# Altium schematic sheet Schematic.SchDoc
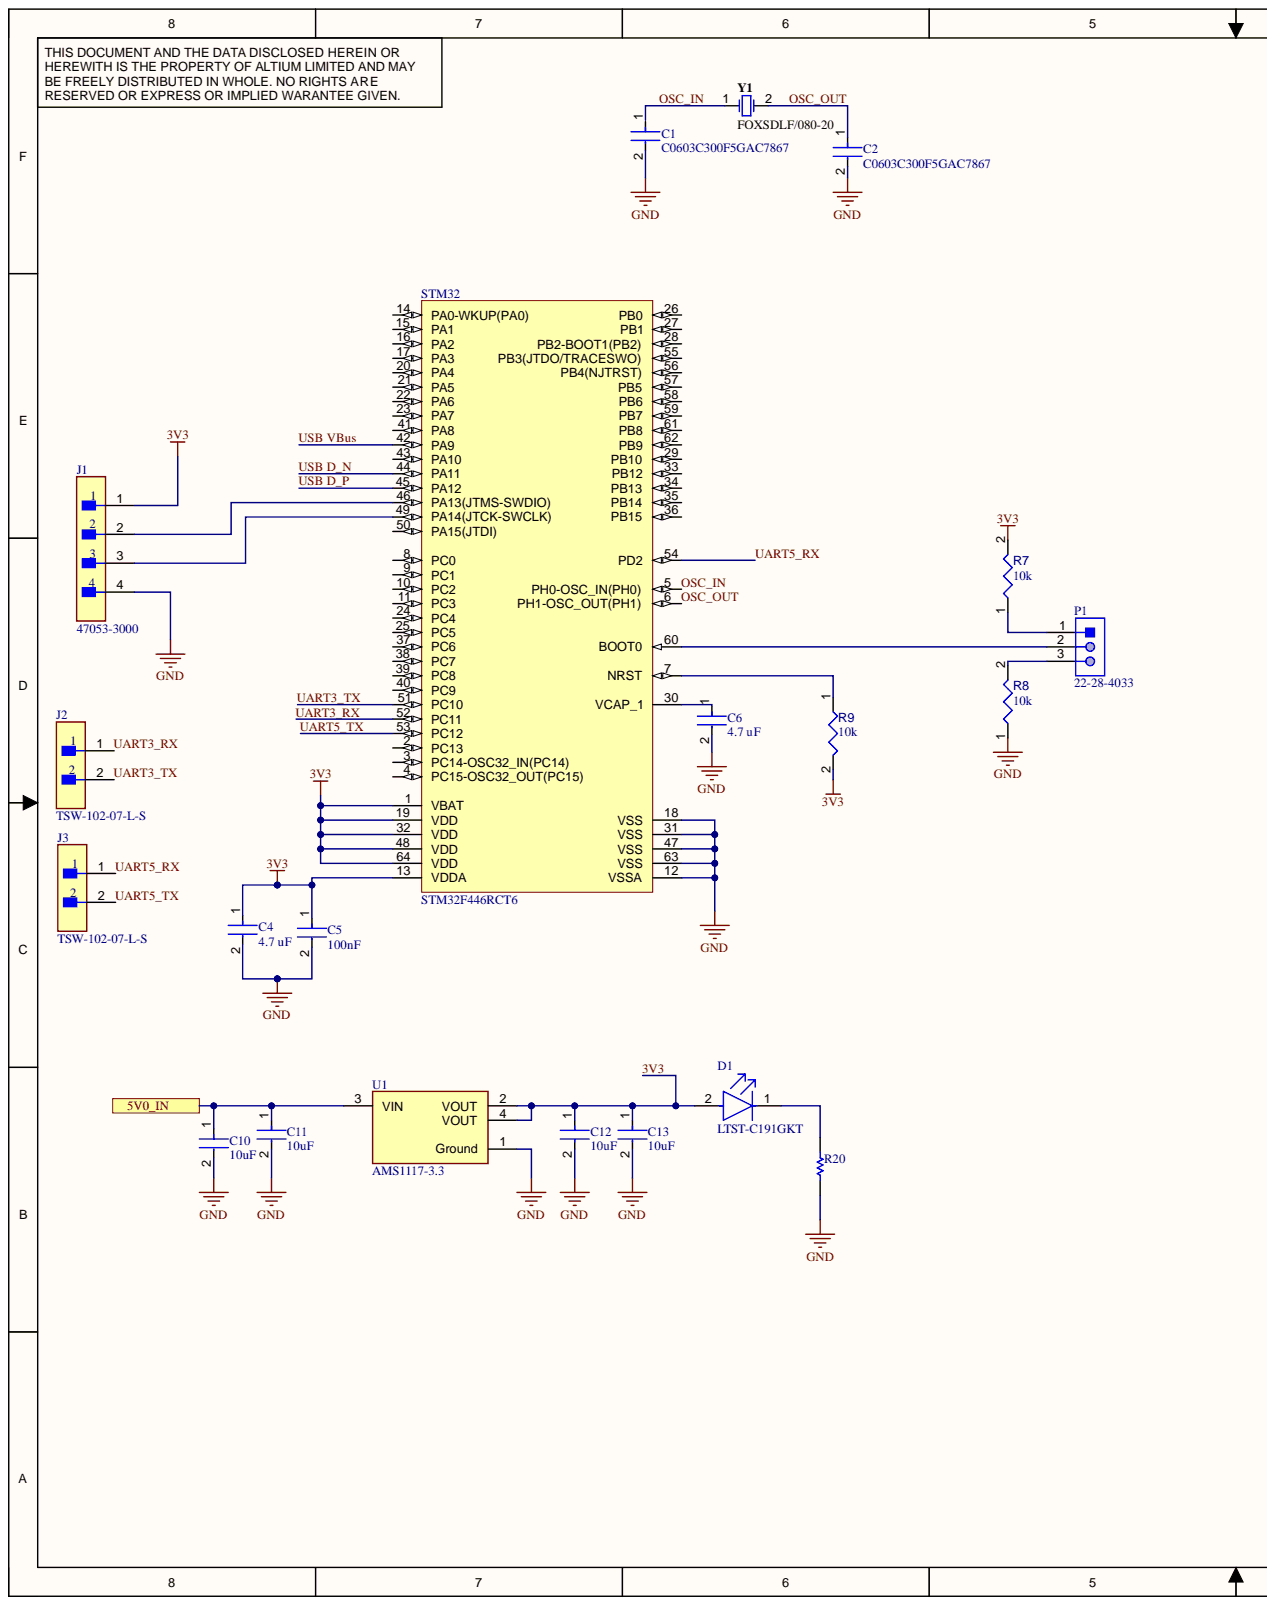
[diagram p0: Schematic.SchDoc, left]
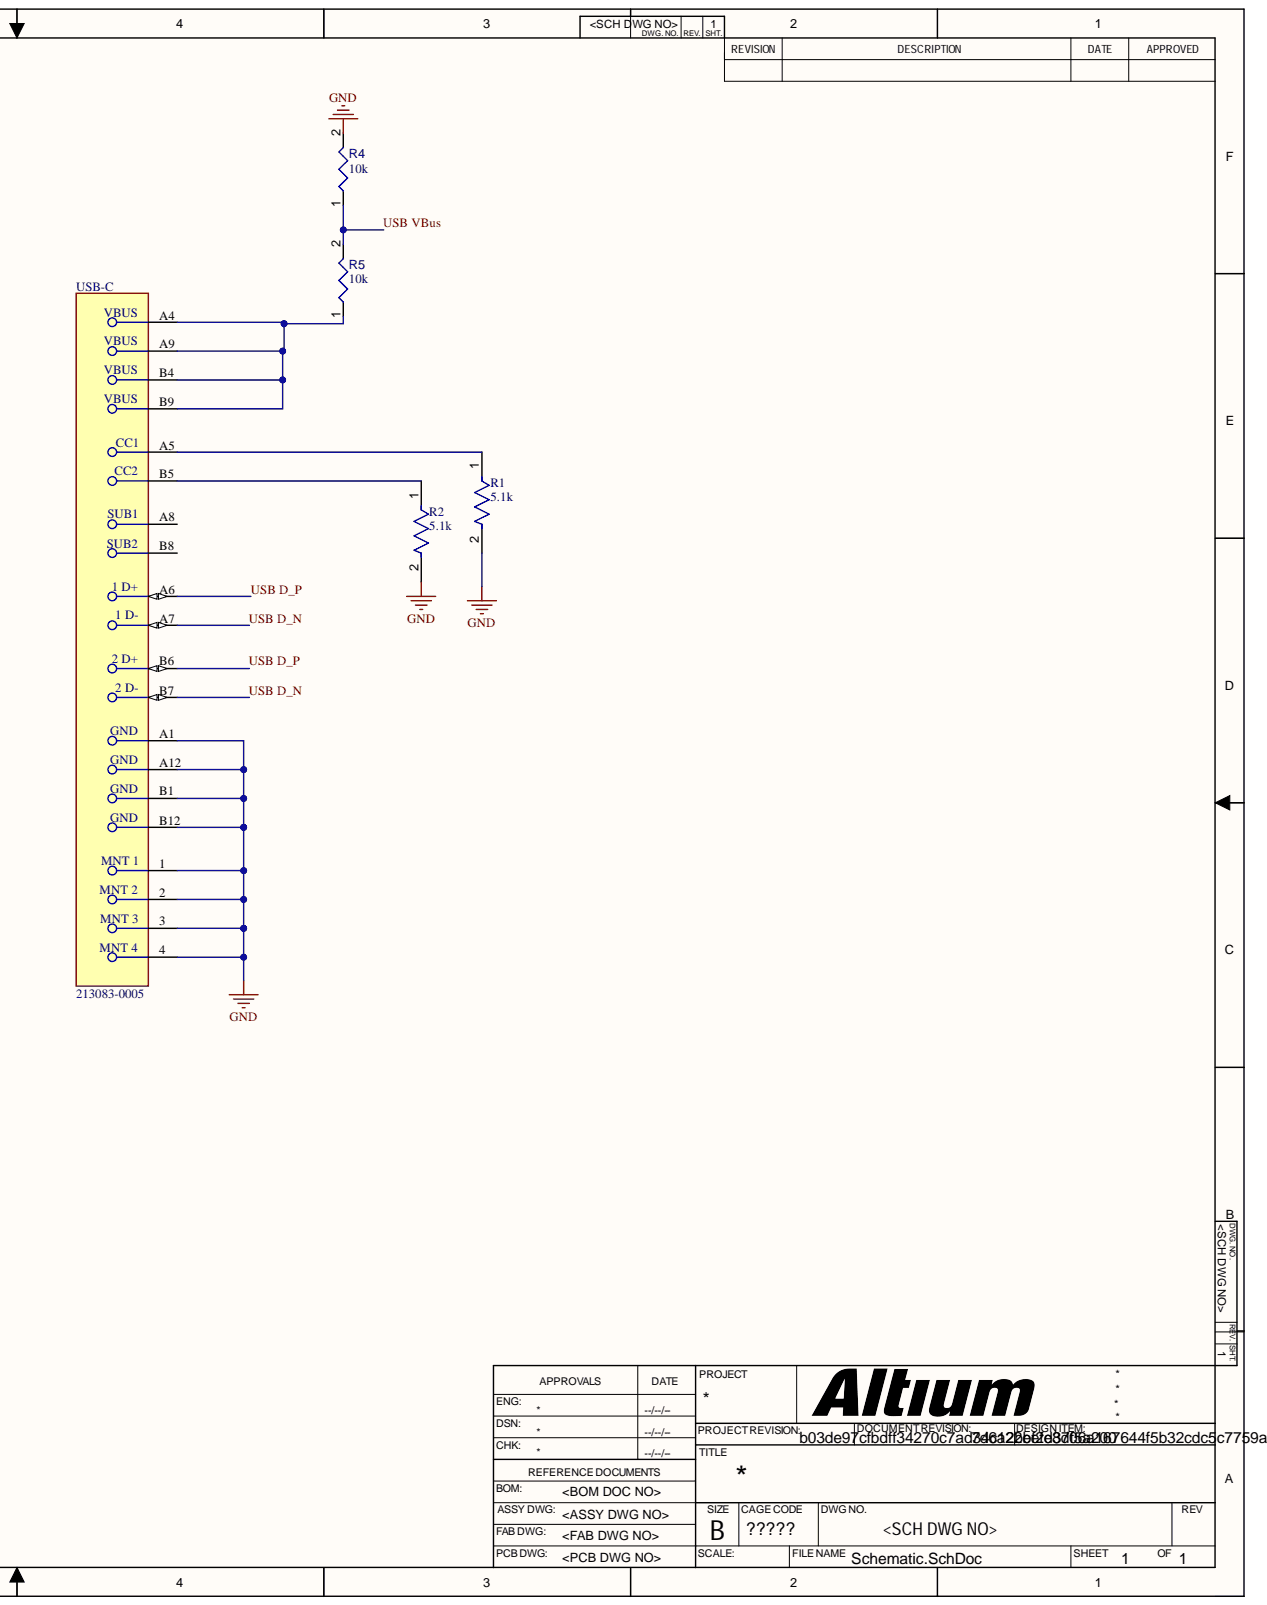
[diagram p1: Schematic.SchDoc, right]

## Parts
C1  C0603C300F5GAC7867  [FP-C0603C-CF-MFG]
C2  C0603C300F5GAC7867  [FP-C0603C-CF-MFG]
C4  4.7 uF  [FP-CC0603-DA-MFG]
C5  100nF  [FP-CGA2B-IPC_C]
C6  4.7 uF  [FP-CC0603-DA-MFG]
C10  10uF  [FP-GRM21B-0_1-MFG]
C11  10uF  [FP-GRM21B-0_1-MFG]
C12  10uF  [FP-GRM21B-0_1-MFG]
C13  10uF  [FP-GRM21B-0_1-MFG]
D1  LTST-C191GKT  [FP-LTST-C191GKT-MFG]
J1  47053-3000  [FP-47053-3000-MFG]
J2  TSW-102-07-L-S  [FP-TSW-102-07-L-S-MFG]
J3  TSW-102-07-L-S  [FP-TSW-102-07-L-S-MFG]
P1  22-28-4033  [MOLX-22-28-4033_V]
R1  5.1k  [FP-MCT0603-IPC_B]
R2  5.1k  [FP-MCT0603-IPC_B]
R4  value 10k  [RESC0402(1005)_L]  (hidden comment: 10KR2F)
R5  value 10k  [RESC0402(1005)_L]  (hidden comment: 10KR2F)
R7  value 10k  [RESC0402(1005)_L]  (hidden comment: 10KR2F)
R8  value 10k  [RESC0402(1005)_L]  (hidden comment: 10KR2F)
R9  value 10k  [RESC0402(1005)_L]  (hidden comment: 10KR2F)
R20  =Value  [RESC3225X60X45ML20T20]
STM32  STM32F446RCT6  [STM-LQFP64_L]
U1  AMS1117-3.3  [FP-AMS1117-IPC_C]
USB-C  213083-0005  [FP-2130830005-MFG]
Y1  FOXSDLF/080-20  [FOX-HC49SDLF_V]

## Ports
5V0_IN

## Nets
GND: C1.2 C2.2 C4.2 C5.2 C6.2 C10.2 C11.2 C12.2 C13.2 J1.4 R1.2 R2.2 R4.2 R8.1 R20.1 STM32.12(VSSA) STM32.18(VSS) STM32.31(VSS) STM32.47(VSS) STM32.63(VSS) U1.1(Ground) USB-C.1 USB-C.2 USB-C.3 USB-C.4 USB-C.A1 USB-C.A12 USB-C.B1 USB-C.B12
3V3: C4.1 C5.1 C12.1 C13.1 D1.2 J1.1 R7.2 R9.2 STM32.1(VBAT) STM32.13(VDDA) STM32.19(VDD) STM32.32(VDD) STM32.48(VDD) STM32.64(VDD) U1.2(VOUT) U1.4(VOUT)
5V0_IN: C10.1 C11.1 U1.3(VIN) ; port 5V0_IN
OSC_IN: STM32.5(PH0-OSC_IN(PH0)) Y1.1
OSC_OUT: C2.1 STM32.6(PH1-OSC_OUT(PH1)) Y1.2
UART3_RX: J2.1 STM32.52(PC11)
UART3_TX: J2.2 STM32.51(PC10)
UART5_RX: J3.1 STM32.54(PD2)
UART5_TX: J3.2 STM32.53(PC12)
USB D_N: STM32.44(PA11) USB-C.A7 USB-C.B7
USB D_P: STM32.45(PA12) USB-C.A6 USB-C.B6
USB VBus: R4.1 R5.2 STM32.42(PA9)
NetC6_1: C6.1 STM32.30(VCAP_1)
NetD1_1: D1.1 R20.2
NetJ1_2: J1.2 STM32.46(PA13(JTMS-SWDIO))
NetJ1_3: J1.3 STM32.49(PA14(JTCK-SWCLK))
NetP1_1: P1.1 R7.1
NetP1_2: P1.2 STM32.60(BOOT0)
NetP1_3: P1.3 R8.2
NetR1_1: R1.1 USB-C.A5
NetR2_1: R2.1 USB-C.B5
NetR5_1: R5.1 USB-C.A4 USB-C.A9 USB-C.B4 USB-C.B9
NetR9_1: R9.1 STM32.7(NRST)

Not connected: C1 1; STM32 2(PC13) 3(PC14-OSC32_IN(PC14)) 4(PC15-OSC32_OUT(PC15)) 8(PC0) 9(PC1) 10(PC2) 11(PC3) 14(PA0-WKUP(PA0)) 15(PA1) 16(PA2) 17(PA3) 20(PA4) 21(PA5) 22(PA6) 23(PA7) 24(PC4) 25(PC5) 26(PB0) 27(PB1) 28(PB2-BOOT1(PB2)) 29(PB10) 33(PB12) 34(PB13) 35(PB14) 36(PB15) 37(PC6) 38(PC7) 39(PC8) 40(PC9) 41(PA8) 43(PA10) 50(PA15(JTDI)) 55(PB3(JTDO/TRACESWO)) 56(PB4(NJTRST)) 57(PB5) 58(PB6) 59(PB7) 61(PB8) 62(PB9); USB-C A8 B8

## Library data of the parts
C1 C2 = CMP-03020-022157-1: Cap Ceramic 30pF 50V C0G 1% SMD 0603 125°C Paper T/R; Manufacturer: KEMET; Automotive Grade: Grade 1; Temperature Coefficient: 30ppm/°C; Tolerance: 0.01; Automotive: Yes; Capacitance: 30 pF; Category: Cap; Component Kind: Standard; ComponentLink2Description: Datasheet; ComponentLink2URL: https://api.kemet.com/component-edge/download/datasheet/C0603C100J5GACAUTO.pdf; Device Class L1: Passive Components; Device Class L2: Capacitors; Device Class L3: Ceramic Capacitors; Digikey Description: Cap Ceramic 30pF 50V C0G 1% SMD 0603 125°C Paper T/R; Height: 0.87mm; Lead Free: Yes; Library Name: Altium Content Vault; Manufacturer Part Number: C0603C300F5GAC7867; Material: Ceramic; Octopart: https://octopart.com/c0603c300f5gac7867-kemet-1596617; Package: C0603C-CF; RoHS: TRUE; Temperature: -55°C to +125°C; Temperature Characteristic: C0G; Temperature Range High: +125°C; Temperature Range Low: -55°C; Value: 30 pF; Voltage Rating: 50 V
C4 C6 = CMP-2000-06074-2: CAP CER 4.7UF 10V X5R 0603; Manufacturer: YAGEO; Manufacturer Part Number: CC0603KRX5R6BB475; Material: Ceramic; Temperature Coefficient: 15%; Tolerance: 0.1; Automotive: No; Capacitance: 4.7 uF; Category: Cap; Component Kind: Standard; ComponentLink2Description: Datasheet; ComponentLink2URL: http://www.yageo.com/documents/recent/UPY-GPHC_X7R_6.3V-to-50V_18.pdf; Device Class L1: Passive Components; Device Class L2: Capacitors; Device Class L3: Ceramic Capacitors; Digikey Description: CAP CER 4.7UF 10V X5R 0603; Footprint Url: http://www.yageo.com/exep/pages/download/literatures/UPY-C_GEN_24.pdf; Height: 0.9mm; Lead Free: Yes; Library Name: Altium Content Vault; Mouser Description: 4.7µF Surface Mount, MLCC 0603 (1608 Metric) Package Ceramic Capacitors; Octopart: https://octopart.com/cc0603krx5r6bb475-yageo-9292507; Package: CC0603-DA; RoHS: TRUE; Temperature: -55°C to +125°C; Temperature Characteristic: X5R; Temperature Range High: +125°C; Temperature Range Low: -55°C; Value: 4.7 uF; Voltage: 10 V; Voltage Rating: 10 V
C5 = CMP-08246-000862-1: Cap Ceramic 100nF 50V X7R 10% Pad SMD 0402 +125°C Automotive T/R; Capacitance: 100nF; Case Code (Imperial): 0402; Case Code (Metric): 1005; Case/Package: 0402; Component Kind: Standard; Features: Soft Termination; Lead Free: Lead Free; Length: 0.039inch; Library Name: Altium Content Vault; Max Operating Temperature: 125°C; Min Operating Temperature: -55°C; Mount: Surface Mount; Packaging: Cut Tape; Ratings: AEC-Q200; Thickness: 0.024inch; Tolerance: 10%; Voltage Rating: 50V; Width: 0.02inch
C10-C13 = CMP-06035-020598-1: =value; Tolerance: 10%; Voltage Rating (DC): 16V; Capacitance: 10uF; Case/Package: 0805; Component Kind: Standard; Depth: 1.25mm; Lead Free: Lead Free; Length: 2mm; Library Name: Altium Content Vault; Max Operating Temperature: 85°C; Min Operating Temperature: -25°C; Packaging: Tape and Reel; Thickness: 1.25mm
D1 = CMP-22017-000029-1: LED GREEN CLEAR CHIP SMD; Dominant Wavelength: 569nm; Forward Current: 30mA; Forward Voltage: 2.1V; Lens Color: Colorless; Luminous Intensity: 12mcd; Number of Elements: 1; Power Dissipation: 100mW; Reverse Voltage: 5V; Test Current: 20mA; Viewing Angle: 130°; Wavelength: 565nm; Case Code (Imperial): 0603; Case Code (Metric): 1608; Case/Package: 0603; Color: Green; Component Kind: Standard; Depth: 0.8mm; Height: 0.55mm; Illumination Color: Green; Lead Free: Lead Free; Length: 1.6mm; Lens Style: Clear; Lens Transparency: Clear; Library Name: Altium Content Vault; Max Operating Temperature: 85°C; Min Operating Temperature: -55°C; Mount: Surface Mount; Number of LEDs: 1; Number of Pins: 2; Package Quantity: 1; Packaging: Tape and Reel; Power Rating: 100mW; Radiation Hardening: No; Width: 0.8mm
J1 = CMP-04776-000447-1: CONN HEADER VERT 4POS 2.54MM; Current Rating: 4A; Gender: Male; Glow Wire Compliant: Non-compliant; Number of Circuits: 4; Voltage Rating: 250V; Component Kind: Standard; Contact Material: Copper Alloy; Contact Plating: Tin; Halogen Free: Low Halogen; Library Name: Altium Content Vault; Max Operating Temperature: 75°C; Min Operating Temperature: 0°C; Mount: Through Hole; Number of Contacts: 4; Number of Positions: 4; Number of Rows: 1; Orientation: Straight; Package Quantity: 12000; Packaging: Bulk; Pitch: 2.54mm; REACH SVHC: No SVHC; RoHS Compliant: Yes; Series: 47053; Termination: Through Hole
J2 J3 = CMP-02766-001428-1: CONN HEADER VERT 2POS 2.54MM; Connector Type: Male Pin; Current Rating: 7.6A; Gender: Male; Max Voltage Rating (AC): 550V; Number of Contacts: 2; Color: Black; Component Kind: Standard; Contact Material: Bronze; Contact Mating Length: 0.23inch; Contact Plating: Gold; Contact Type: Male Pin; Depth: 2.54mm; Housing Color: Black; Housing Material: Polyester; Lead Free: Lead Free; Length: 5.08mm; Library Name: Altium Content Vault; Max Current Rating: 7.6A; Max Operating Temperature: 125°C; Min Operating Temperature: -55°C; Mount: Through Hole; Number of Positions: 2; Number of Rows: 1; Orientation: Straight; Package Quantity: 1; Packaging: Bulk; Pitch: 2.54mm; Radiation Hardening: No; REACH SVHC: No SVHC; RoHS Compliant: Yes; Series: TSW; Termination: Solder; Voltage Rating: 550V; Width: 2.54mm
P1 = CMP-1676-00001-5: Male Header, Pitch 2.54 mm, 1 x 3 Position, Height 8.38 mm, Tail Length 3.18 mm, RoHS, Bulk; Manufacturer: Molex; Current Rating: 4 A; Rows: 1; Voltage Rating: 250 V; Colour: Black; Component Kind: Standard; ComponentLink1Description: Manufacturer URL; ComponentLink1URL: http://www.molex.com/; ComponentLink2Description: Datasheet; ComponentLink2URL: http://www.molex.com/pdm_docs/sd/022284033_sd.pdf; Contact Finish: Gold; Contact Material: Brass; Contact Plating: Gold; DatasheetVersion: Rev. G, 01/2012; Height: 8.38 mm; Lead Pitch: 0.1 inch; Length: 7.62 mm; Library Name: Altium Content Vault; Max Operating Temperature: 75 degC; Min Operating Temperature: 0 degC; Mount: Through Hole; Mounting Technology: Through Hole; Package Quantity: 1; PackageDescription: Male Header, Pitch 2.54 mm, 1 x 3 Position, Height 8.38 mm, Tail Length 3.18 mm; PackageReference: 22-28-4033; Packaging: Bulk; Pins: 3; REACH SVHC: Unknown; RoHSCompliant: true
R1 R2 = CMP-02407-010205-1: RES SMD 100 OHM 1% 1/8W 0603; Resistance: 5.1kR; Temperature Coefficient: 25ppm/°C; Tolerance: 0.1%; Case/Package: 0603; Component Kind: Standard; Composition: Thin Film; Contact Plating: Tin; Height: 450um; Lead Free: Lead Free; Length: 1.55mm; Library Name: Altium Content Vault; Max Operating Temperature: 125°C; Min Operating Temperature: -55°C; Radiation Hardening: No; Width: 850um
R4 R5 R7-R9 = CMP-009-00066-2: 10K 0.063W 1% 0402 (1005 Metric)  SMD; Power: 63mW; Case/Package: 0402; Component Kind: Standard; Mounting Technology: SMT; Pins: 2; Tolerance: 1%; Library Name: 21jng3 21jng3
R20 = CMP-2005-00707-1: Manufacturer: Vishay Dale; Part Number: CRCW1210330RJNTA; Component Kind: Standard; Library Name: Altium Content Vault
STM32 = CMP-0243-00216-1: ARM Cortex-M4 32-bit MCU+FPU, 256 KB Flash, 128 KB Internal RAM, 50 I/Os, 64-Pin LQFP, -40 to 85 degC, Tray; D/A Converter: 2; FLASH Size (Prog) (kB): 256; I/Os (High Current): 50; Internal RAM Size (kB): 128; Operating Frequency(F) (Processor speed) (MHz): 180; Supply Voltage(Vcc) min (V): 1.7; Component Kind: Standard; ComponentLink1Description: Manufacturer URL; ComponentLink1URL: http://www.st.com/; ComponentLink2Description: Datasheet; ComponentLink2URL: http://www.st.com/st-web-ui/static/active/en/resource/technical/document/datasheet/DM00141306.pdf; Core: ARM Cortex-M4; DatasheetVersion: Rev. 6; Library Name: Altium Content Vault; Mounting Technology: Surface Mount; Package Description: LQFP64; Package Reference: 64-Pin Low-profile Quad Flat Package, Body 10 x 10 mm, Pitch 0.5 mm; Packing: Tray
U1 = CMP-209535-000001-1: LDO Voltage Regulators 1A, 3.3V; Manufacturer: Advanced Monolithic Systems; Dropout Voltage: 1.1V; Max Junction Temp: +125°C; Max Power Dissipation: 1.2W; Max Supply Voltage: 15V; Nominal Supply Current: 5mA; Number Of Outputs: 1; Output Type: Fixed; Standoff Height: 0.64mm; Automotive: No; Category: IC; Component Kind: Standard; ComponentLink2Description: Datasheet; ComponentLink2URL: http://www.advanced-monolithic.com/pdf/ds1117.pdf; Device Class L1: Integrated Circuits (ICs); Device Class L2: Power Management ICs; Device Class L3: Voltage Regulators - Linear; Height: 1.8mm; Ipc Land Pattern Name: SOT229P700X180-4; Lead Free: Yes; Library Name: Altium Content Vault; Manufacturer Part Number: AMS1117-3.3; Octopart: https://octopart.com/ams1117-3.3-advanced+monolithic+systems-25899300; Output Current: 1A; Output Voltage: 3.3V; Package: AMS1117; RoHS: TRUE; Temperature: -40°C to +125°C; Temperature Range High: +125°C; Temperature Range Low: -40°C
USB-C = CMP-04767-000030-2: CONN RCP USB2.0 TYP C 24P SMD RA; NexarPartId: 114105384; Current Rating: 5A; Gender: Receptacle; Number of Contacts: 16; Voltage Rating: 30V; Component Kind: Standard; Contact Material: Gold, Nickel; Country of Origin: CN; Library Name: Altium Content Vault; Max Operating Temperature: 85°C; Min Operating Temperature: -40°C; Schedule B: 8536695050, 8536695050; USB Standard: USB 2.0
Y1 = CMP-2100-03639-1: Resistance Weld Crystal, 8 MHz, +/- 30 ppm, -20 to 70 degC, 2-Pin SMD, RoHS, Tape and Reel; Manufacturer: FOX Electronics; ESR (Equivalent Series Resistance): 80Ohm; Frequency: 8MHz; Frequency Stability: 50ppm; Frequency Tolerance: 30ppm; Load Capacitance: 20pF; Case/Package: SMD/SMT; Component Kind: Standard; Datasheet URL: http://www.foxonline.com/pdfs/hc49sdlf.pdf; Datasheet Version: 42064.0; Depth: 5mm; Height: 4.5mm; Lead Free: LeadFree; Length: 11.7mm; Library Name: Altium Content Vault; Manufacturer URL: http://www.foxonline.com/; Max Operating Temperature: 70degC; Min Operating Temperature: -20degC; Mount: SurfaceMount; Number of Pins: 2; Package Description: 2-Pin Surface Mount Device, Body 5 x 11.7 mm; Package Quantity: 1000; Package Reference: HC49SDLF; Packaging: TapeandReel; Radiation Hardening: No; REACH SVHC: NoSVHC; RoHSCompliant: True; Series Resistance: 80Ohm; Termination: SMD/SMT; Tolerance: 50ppm; Width: 5mm
